# Altium schematic sheet 液晶液晶5V.Sch
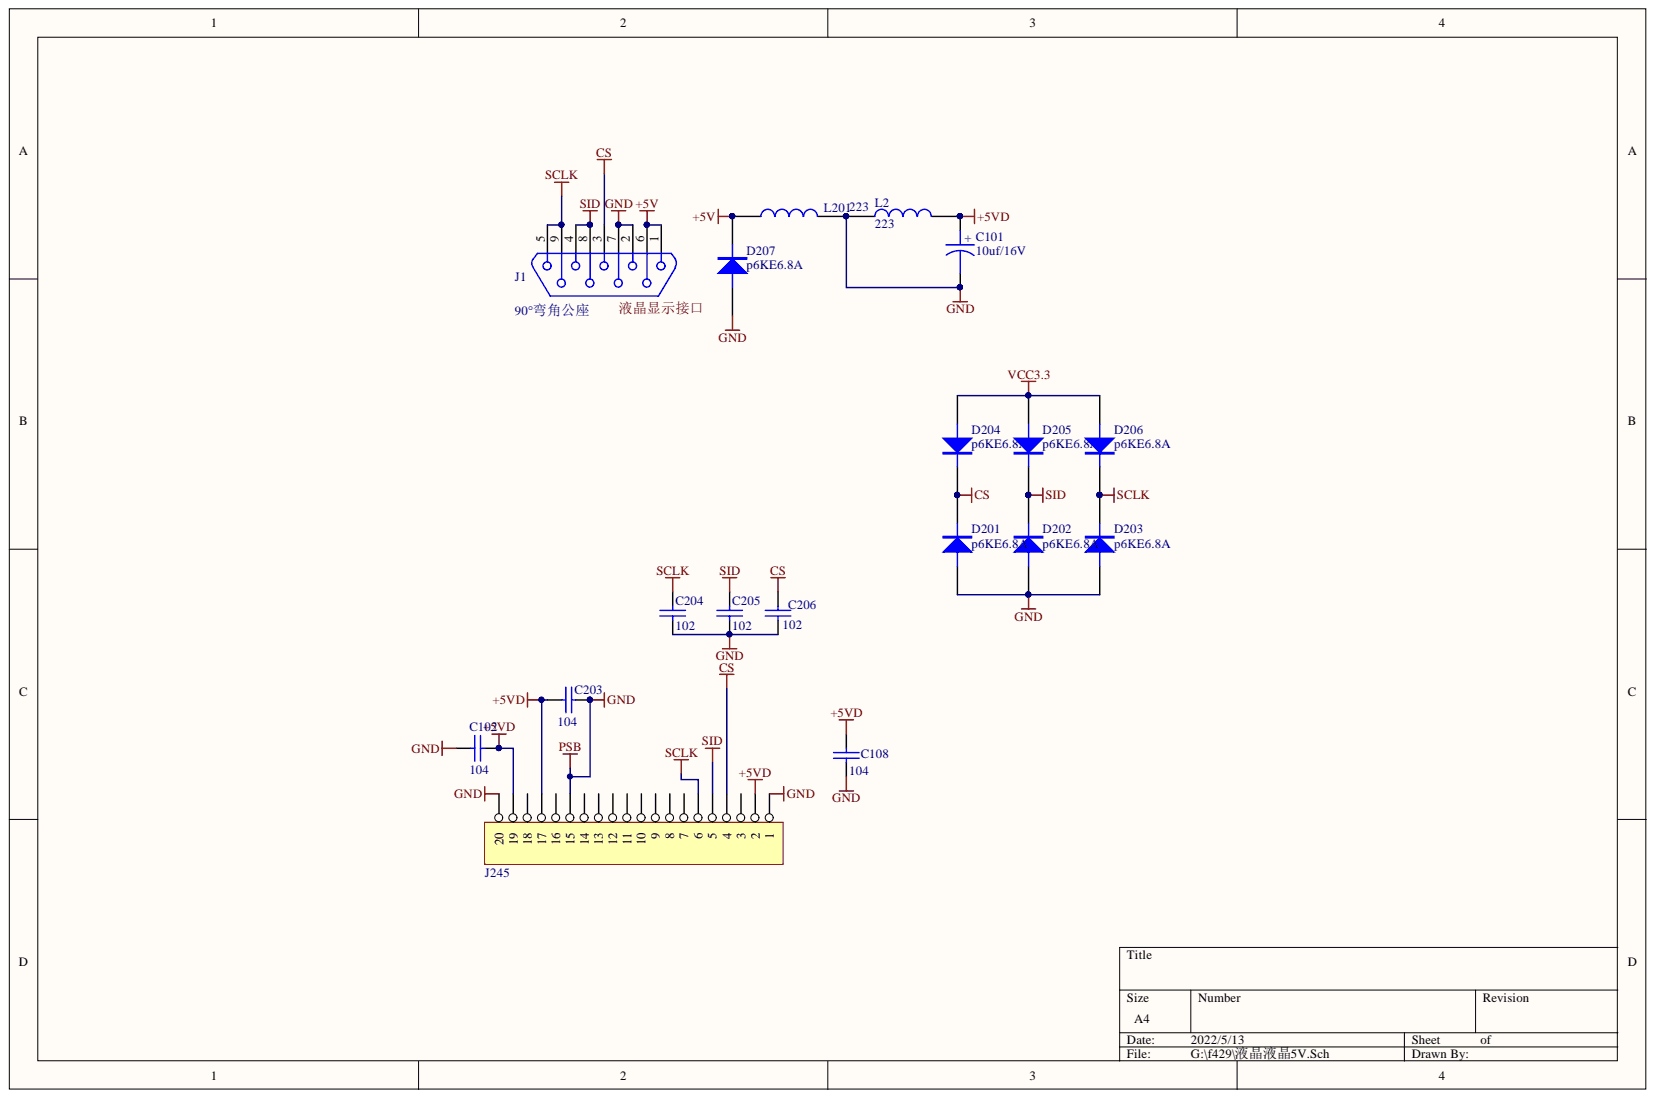
[diagram p0: 液晶液晶5V.Sch]

## Parts
C101  10uf/16V
C102  104
C108  104
C203  104
C204  102
C205  102
C206  102
D201  p6KE6.8A
D202  p6KE6.8A
D203  p6KE6.8A
D204  p6KE6.8A
D205  p6KE6.8A
D206  p6KE6.8A
D207  p6KE6.8A
J1  90°弯角公座  [DB-9/M]
J245  (no value)
L2  223
L201  223  (value not drawn)

## Nets
+5V: D207.2 J1.1 J1.6 L201.1
+5VD: C101.1 C102.1 C108.2 C203.1 J245.2 J245.17 J245.19 L2.2
CS: C206.1 D201.2 D204.2 J1.3 J245.4
GND: C101.2 C102.2 C108.1 C203.2 C204.2 C205.1 C206.2 D201.1 D202.1 D203.1 D207.1 J1.2 J1.7 J245.1 J245.15 J245.20 L2.1 L201.2
SCLK: C204.1 D203.2 D206.2 J1.5 J1.9 J245.6
SID: C205.2 D202.2 D205.2 J1.4 J1.8 J245.5
VCC3.3: D204.1 D205.1 D206.1
液晶显示接口:

Not connected: J245 3 7 8 9 10 11 12 13 14 16 18

## Library data of the parts
C101 = CAPACITOR POL: Capacitor; Component Kind: Standard
C102 C108 C203-C206 = CAP: Capacitor; Component Kind: Standard
D201-D207 = DIODE: Component Kind: Standard; Description: Diode
J1 = DB9: Component Kind: Standard
J245 = HEADER 20: Component Kind: Standard
L2 L201 = INDUCTOR: Component Kind: Standard
